# Altium schematic sheet Power.SchDoc
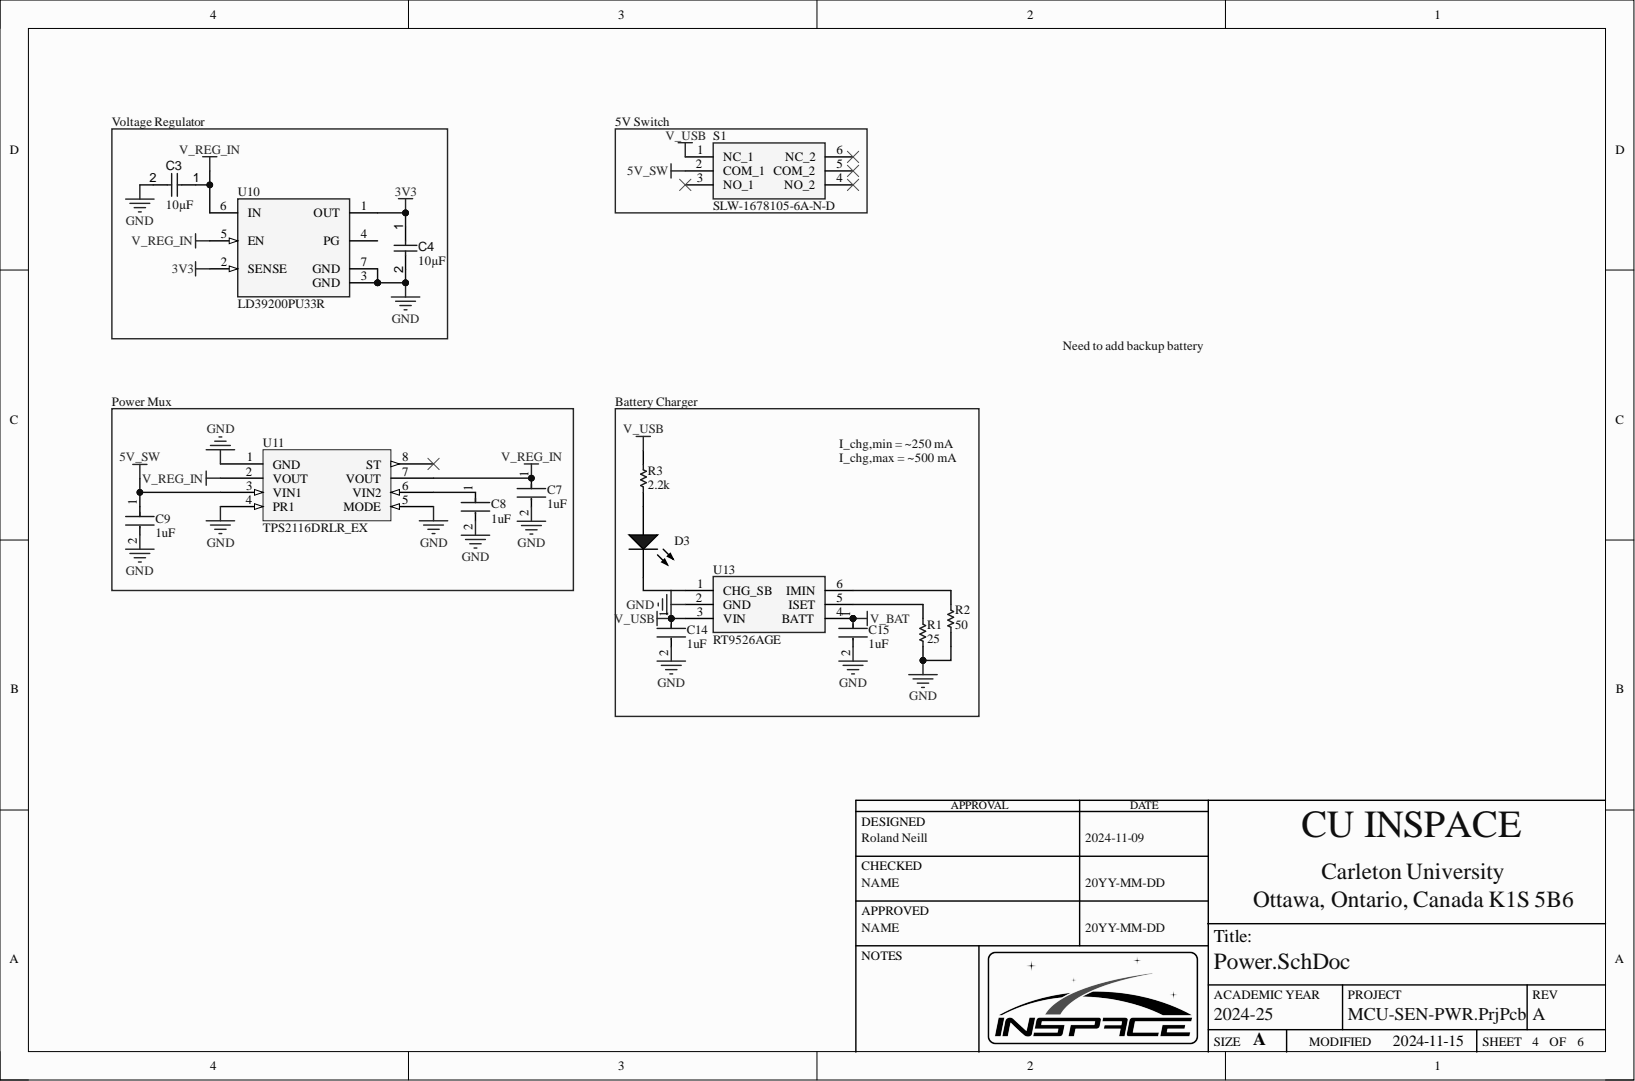
[diagram p0: Power.SchDoc]

## Parts
C3  value 10µF  [CAPC0805(2012)145_L]  (hidden comment: Capacitor 10µF +/-20% 25V 0805)
C4  value 10µF  [CAPC0805(2012)145_L]  (hidden comment: Capacitor 10µF +/-20% 25V 0805)
C7  value 1uF  [FP-CL21-IPC_B]  (hidden comment: CL21F105ZAFNNNF)
C8  value 1uF  [FP-CL21-IPC_B]  (hidden comment: CL21F105ZAFNNNF)
C9  value 1uF  [FP-CL21-IPC_B]  (hidden comment: CL21F105ZAFNNNF)
C14  value 1uF  [FP-CL21-IPC_B]  (hidden comment: CL21F105ZAFNNNF)
C15  value 1uF  [FP-CL21-IPC_B]  (hidden comment: CL21F105ZAFNNNF)
D3  TLMB1100-GS08  [VISH-TLMX1100-2_V]  (value not drawn)
R1  value 25  [FP-CRCW0603-e3-IPC_C]  (hidden comment: CRCW060325R0FKEA)
R2  value 50  [FP-FC0603-IPC_A]  (hidden comment: FC0603E50R0BTBST1)
R3  value 2.2k  [FP-RMCF0805-MFG]  (hidden comment: RMCF0805JT2K20)
S1  SLW-1678105-6A-N-D  [SLW-1678105-6A-N-D-Footprint-1]
U10  LD39200PU33R  [FP-DFN6-7946637-MFG]
U11  TPS2116DRLR_EX  [TPS2115]
U13  RT9526AGE  [RT9526AGE-Footprint-1]

## Nets
3V3: C4.1 U10.1(OUT) U10.2(SENSE)
5V_SW: C9.1 S1.2(COM_1) U11.3(VIN1)
GND: C3.2 C4.2 C7.2 C8.2 C9.2 C14.2 C15.2 R1.2 R2.2 U10.3(GND) U10.7(GND) U11.1(GND) U11.4(PR1) U11.5(MODE) U13.2(GND)
V_BAT: C15.1 U13.4(BATT)
V_REG_IN: C3.1 C7.1 U10.5(EN) U10.6(IN) U11.2(VOUT) U11.7(VOUT)
V_USB: C14.1 R3.2 S1.1(NC_1) U13.3(VIN)
NetC8_1: C8.1 U11.6(VIN2)
NetD3_1: D3.1 R3.1
NetD3_2: D3.2 U13.1(CHG_SB)
NetR1_1: R1.1 U13.5(ISET)
NetR2_1: R2.1 U13.6(IMIN)

Not connected: S1 3(NO_1) 4(NO_2) 5(COM_2) 6(NC_2); U10 4(PG); U11 8(ST)

## Library data of the parts
C3 C4 = CMP-001-00079-4: Chip Capacitor, 10µF +/-20%, 25V, 0805, Thickness 1.45 mm; Tolerance: 10%; Case/Package: 0805; Component Kind: Standard; Max Operating Temperature: 85°C; Min Operating Temperature: -55°C; Mounting Technology: SMT; Pins: 2; Voltage Rating: 25V; Library Name: Americas- CU InSpace-  Carleton University
C7-C9 C14 C15 = CMP-001-00083-1: Cap Ceramic 1uF 25V Y5V -20% to 80% SMD 0805 85°C Embossed T/R; Case/Package: 0805; Component Kind: Standard; Construction: Flat; Depth: 1.25mm; Dielectric: Y5V; Height: 1.25mm; Length: 2mm; Max Operating Temperature: 85°C; Min Operating Temperature: -30°C; Mounting Technology: Surface Mount; Package Quantity: 10000; Packaging: Tape and Reel; Radiation Hardening: No; RoHS Compliant: Yes; Series: CL; Thickness: 0.053inch; Tolerance: 80%; Voltage Rating: 25V; Voltage Rating (DC): 25V; Width: 0.049inch; Library Name: Americas- CU InSpace-  Carleton University
D3 = CMP-007-00028-2: Blue LED, 10 mA, 3.9 V, -40 to 100 degC, 2-Pin SMD (0603), RoHS, Tape and Reel; Manufacturer: Vishay Semiconductor; Forward Current: 10mA; Forward Voltage: 3.9V; Lens Style: Diffused; Luminous Intensity: 5mcd; Power: 68mW; Viewing Angle: 160degrees; Case/Package: 0603; Colour: Blue; Component Kind: Standard; Datasheet URL: http://download.siliconexpert.com/pdfs/2016/8/7/12/43/26/667/vsh_/manual/379263299541529tlmb1100.pdf; Datasheet Version: Rev. 2.7, 03/2016; Illumination Colour: Blue; Manufacturer URL: http://www.vishay.com/; Max Operating Temperature: 100°C; Min Operating Temperature: -40°C; Mounting Technology: SM; Nominal Vf: 3.9V; Package Description: 2-Pin Surface Mount Device, Body 1.6 x 0.8 mm; Package Quantity: 3000; Package Reference: 0603; Packaging: TapeandReel; Pins: 2; RoHS Compliant: True; Library Name: Americas- CU InSpace-  Carleton University
R1 = CMP-009-00194-2: Fixed Resistor, Metal Glaze/thick Film, 0.1W, 25ohm, 75V, 1% +/-Tol, 100ppm/Cel, Surface Mount, 0603; Power: 100mW; Rated Temperature: 70°C; Reference Standard: AEC-Q200; Temperature Coefficient: 100ppm/°C; Case/Package: 0603; Component Kind: Standard; JESD-609 Code: e3; Max Operating Temperature: 155°C; Min Operating Temperature: -55°C; Mounting Technology: Surface Mount; Package Shape: Rectangular; Packaging: Tape and Reel; Pins: 2; Reach SVHC Compliant: Yes; Resistor Type: FIXED RESISTOR; RoHS Compliant: Yes; Technology: METAL GLAZE/THICK FILM; Terminal Finish: Tin; Termination: Wraparound; Tolerance: 1%; Working Voltage: 75V; Library Name: Americas- CU InSpace-  Carleton University
R2 = CMP-009-00193-2: Fixed Resistor, Thin Film, 0.125W, 50ohm, 50V, 0.1% +/-Tol, 25ppm/Cel, Surface Mount, 0603; Package Height: 0.381mm; Package Length: 1.626mm; Package Width: 0.813mm; Power: 125mW; Temperature Coefficient: 25ppm/°C; Case/Package: 0603; Component Kind: Standard; Construction: Chip; Max Operating Temperature: 125°C; Min Operating Temperature: -55°C; Mounting Technology: Surface Mount; Package Shape: Rectangular; Packaging: Tape and Reel; Pins: 2; Resistor Type: FIXED RESISTOR; RoHS Compliant: Yes; Technology: THIN FILM; Terminal Finish: Copper; Termination: Wraparound; Tolerance: 0.1%; Working Voltage: 50V; Library Name: Americas- CU InSpace-  Carleton University
R3 = CMP-009-00195-2: Fixed Resistor, Metal Glaze/thick Film, 0.125W, 2200ohm, 150V, 5% +/-Tol, 200ppm/Cel, Surface Mount, 0805; Package Height: 0.5mm; Package Length: 2mm; Package Width: 1.25mm; Power: 125mW; Rated Temperature: 70°C; Reference Standard: AEC-Q200; Temperature Coefficient: 200ppm/°C; Case/Package: 0805; Component Kind: Standard; Construction: Rectangular; JESD-609 Code: e3; Max Operating Temperature: 155°C; Min Operating Temperature: -55°C; Mounting Technology: Surface Mount; Package Shape: Rectangular; Packaging: Tape and Reel; Pins: 2; Reach SVHC Compliant: Yes; Resistor Type: FIXED RESISTOR; RoHS Compliant: Yes; Technology: METAL GLAZE/THICK FILM; Terminal Finish: Nickel; Termination: Wraparound; Tolerance: 5%; Working Voltage: 150V; Library Name: Americas- CU InSpace-  Carleton University
S1 = CMP-011-00014-2: 16 X 7.8 X 10.5 Mm, 6 Mm Raised; Component Kind: Standard; Library Name: Americas- CU InSpace-  Carleton University
U10 = CMP-019-00061-1: Fixed Positive LDO Regulator, 3.3V, 0.25V Dropout; Channels: 1; Max Supply Voltage: 6V; Min Input Voltage: 4.3V; Package Body Material: Plastic; Regulator Type: FIXED POSITIVE SINGLE OUTPUT LDO REGULATOR; Case/Package: HVSON; Component Kind: Standard; Height - Seated (Max): 1mm; JESD-30 Code: S-PDSO-N6; Length: 3mm; Operating Temperature, TJ-Max: 125°C; Operating Temperature, TJ-Min: -40°C; Output Voltage1-Nom: 3.3V; Package Shape: Square; Package Style: SMALL OUTLINE, HEAT SINK/SLUG, VERY THIN PROFILEMeter; Pins: 6; Reach SVHC Compliant: Yes; RoHS Compliant: Yes; Surface Mount: Yes; Terminal Form: No Lead; Terminal Pitch: 0.95mm; Terminal Position: DUAL; Width: 3mm; Library Name: Americas- CU InSpace-  Carleton University
U11 = CMP-00025-4: 1.6-V to 5.5-V, 40-mΩ, 2.5-A, low-IQ, priority power multiplexer 8-SOT-5X3 -40 to 125; Component Kind: Standard; Library Name: Americas- CU InSpace-  Carleton University
U13 = CMP-019-00060-1: Power Supply Support Circuit; Component Kind: Standard; Library Name: Americas- CU InSpace-  Carleton University
